# Altium schematic sheet RF Frontend v1.0.0.SchDoc("RF Frontend v1.0.0")
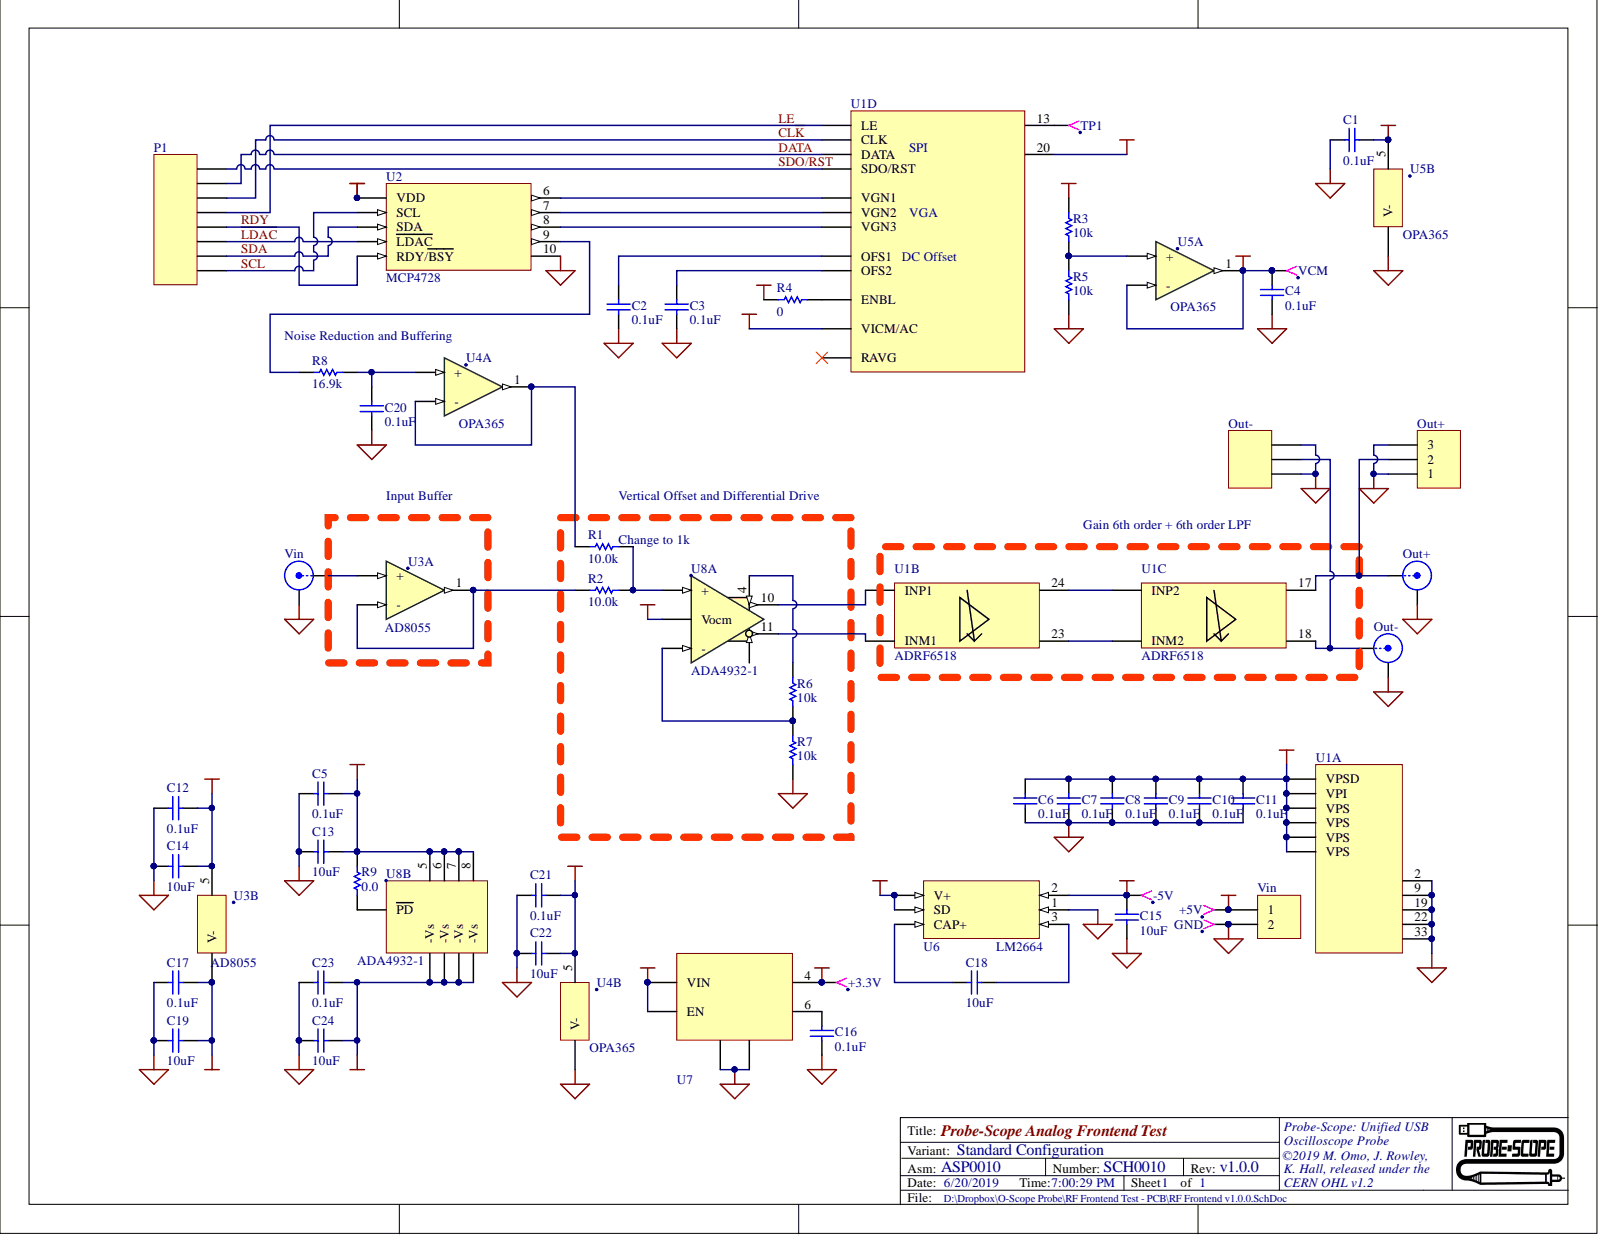
[diagram p0: RF Frontend v1.0.0.SchDoc]

## Parts
+3.3V  TP  [TESTPOINT062]  (value not drawn)
+5V  TP  [TESTPOINT062]  (value not drawn)
-5V  TP  [TESTPOINT062]  (value not drawn)
C1  value 0.1uF  [0402(1005)]  (hidden comment: Cap)
C2  value 0.1uF  [0402(1005)]  (hidden comment: Cap)
C3  value 0.1uF  [0402(1005)]  (hidden comment: Cap)
C4  value 0.1uF  [0402(1005)]  (hidden comment: Cap)
C5  value 0.1uF  [0402(1005)]  (hidden comment: Cap)
C6  value 0.1uF  [0402(1005)]  (hidden comment: Cap)
C7  value 0.1uF  [0402(1005)]  (hidden comment: Cap)
C8  value 0.1uF  [0402(1005)]  (hidden comment: Cap)
C9  value 0.1uF  [0402(1005)]  (hidden comment: Cap)
C10  value 0.1uF  [0402(1005)]  (hidden comment: Cap)
C11  value 0.1uF  [0402(1005)]  (hidden comment: Cap)
C12  value 0.1uF  [0402(1005)]  (hidden comment: Cap)
C13  value 10uF  [0603(1608)]  (hidden comment: 25V)
C14  value 10uF  [0603(1608)]  (hidden comment: 25V)
C15  value 10uF  [0603(1608)]  (hidden comment: 25V)
C16  value 0.1uF  [0402(1005)]  (hidden comment: Cap)
C17  value 0.1uF  [0402(1005)]  (hidden comment: Cap)
C18  value 10uF  [0603(1608)]  (hidden comment: 25V)
C19  value 10uF  [0603(1608)]  (hidden comment: 25V)
C20  value 0.1uF  [0402(1005)]  (hidden comment: Cap)
C21  value 0.1uF  [0402(1005)]  (hidden comment: Cap)
C22  value 10uF  [0603(1608)]  (hidden comment: 25V)
C23  value 0.1uF  [0402(1005)]  (hidden comment: Cap)
C24  value 10uF  [0603(1608)]  (hidden comment: 25V)
GND  TP  [TESTPOINT062]  (value not drawn)
Out+  SMA  [TE SMA Stright]  (value not drawn)
Out+  0.1"  [0.1HDR1X3]  (value not drawn)
Out-  SMA  [TE SMA Stright]  (value not drawn)
Out-  0.1"  [0.1HDR1X3]  (value not drawn)
P1  0.1" Vert  [0.1HDR1X8]  (value not drawn)
R1  value 10.0k  [0603(1608)]  (hidden comment: Res1)
R2  value 10.0k  [0603(1608)]  (hidden comment: Res1)
R3  value 10k  [0402(1005)]  (hidden comment: Res1)
R4  value 0  [0402(1005)]  (hidden comment: Res1)
R5  value 10k  [0402(1005)]  (hidden comment: Res1)
R6  value 10k  [0402(1005)]  (hidden comment: Res1)
R7  value 10k  [0402(1005)]  (hidden comment: Res1)
R8  value 16.9k  [0603(1608)]  (hidden comment: Res1)
R9  value 0.0  [0603(1608)]  (hidden comment: Res1)
TP1  TP  [TESTPOINT062]
U1  ADRF6518  Type: VGA  [QFN50P500X500X80_HS-33L]  (parts A,B,C,D)
U2  MCP4728  [MSOP-10]
U3  AD8055  [SOT95P280X145-5L]  (parts A,B)
U4  OPA365  [SOT23-5]  (parts A,B)
U5  OPA365  [SOT23-5]  (parts A,B)
U6  LM2664  [SOT95P280X145-6N]
U7  MIC5353  [MLF22D-06]  (value not drawn)
U8  ADA4932-1  [QFN50P300X300X80_HS-17L]  (parts A,B)
VCM  TP  [TESTPOINT062]  (value not drawn)
Vin  SMA  [TE SMA Stright]  (value not drawn)
Vin  0.1"  [0.1HDR1X2P]  (value not drawn)

## Nets
3V3: +3.3V.1 C1.2 C6.2 C7.2 C8.2 C9.2 C10.2 C11.2 C21.2 C22.2 R3.2 R4.1 U1.1(VPSD) U1.8(VPI) U1.12(VPS) U1.16(VPS) U1.25(VPS) U1.29(VPS) U2.1(VDD) U4.5 U5.5 U7.4
5V: +5V.1 C5.2 C12.2 C13.2 C14.2 R9.1 U3.5 U6.4(SD) U6.5(V+) U7.1(EN) U7.3(VIN) U8.5 U8.6 U8.7 U8.8 Vin.1
-5V: -5V.1 C15.2 C17.2 C19.2 C23.2 C24.2 U3.2(V-) U6.2 U8.13(-Vs) U8.14(-Vs) U8.15(-Vs) U8.16(-Vs)
GND: C1.1 C2.1 C3.1 C4.1 C5.1 C6.1 C7.1 C8.1 C9.1 C10.1 C11.1 C12.1 C13.1 C14.1 C15.1 C16.1 C17.1 C19.1 C20.1 C21.1 C22.1 C23.1 C24.1 GND.1 Out+.1 Out+.2 Out+.3 Out-.1 Out-.2 Out-.3 R5.1 R7.1 U1.2 U1.9 U1.19 U1.22 U1.33 U2.10 U4.2(V-) U5.2(V-) U6.1 U7.2 U7.7 Vin.2
VCM: C4.2 U1.7(VICM/AC) U1.20 U5.1 U5.4(-) U8.9(Vocm) VCM.1
CL~{K}: P1.3 U1.4(CLK)
DATA: P1.2 U1.5(DATA)
~{LDAC}: P1.6 U2.4(LDAC)
LE: P1.4 U1.3(LE)
RDY: P1.5 U2.5(RDY/BSY)
SCL: P1.8 U2.2(SCL)
SDA: P1.7 U2.3(SDA)
SDO/RST: P1.1 U1.6(SDO/RST)
NetC2_2: C2.2 U1.26(OFS1)
NetC3_2: C3.2 U1.15(OFS2)
NetC16_2: C16.2 U7.6
NetC18_1: C18.1 U6.6(CAP+)
NetC18_2: C18.2 U6.3
NetC20_2: C20.2 R8.2 U4.3(+)
NetOut+_1: Out+.1 Out+.2 U1.17
NetOut-_1: Out-.1 Out-.2 U1.18
NetR1_1: R1.1 U4.1 U4.4(-)
NetR1_2: R1.2 R2.2 U8.2(+)
NetR2_1: R2.1 U3.1 U3.4(-)
NetR3_1: R3.1 R5.2 U5.3(+)
NetR4_2: R4.2 U1.32(ENBL)
NetR6_1: R6.1 R7.2 U8.3(-)
NetR6_2: R6.2 U8.4
NetR8_1: R8.1 U2.9
NetR9_2: R9.2 U8.12(PD)
NetTP1_1: TP1.1 U1.13
NetU1_10: U1.10(INP2) U1.24
NetU1_11: U1.11(INM2) U1.23
NetU1_14: U1.14(VGN2) U2.7
NetU1_21: U1.21(VGN3) U2.8
NetU1_27: U1.27(VGN1) U2.6
NetU1_30: U1.30(INM1) U8.11
NetU1_31: U1.31(INP1) U8.10
NetU3_3: U3.3(+) Vin.1

Not connected: U1 28(RAVG); U8 1

## Library data of the parts
+3.3V +5V -5V GND TP1 VCM = 57: VENDOR_PN: 5005K-ND; VENDOR: Digikey; P&V Description: Connector, Test Point, Red, Loop, Thru Hole, 0.22", 0.063" Dia; Other: Red, Loop, Thru Hole, 0.22", 0.063" Dia; MENG_PN: CON00004; MANF_PN: 5005; MANF: Keystone Electronics; Database Table Name: Connectors; Class: Connector; Approved: True; Verified: True; Type: Test Point; SMD: False; Signal Integrity: Connector; Parent Key: 57; Library Name: MENG Database Library.DbLib; Key: 57; Date Modified: 6/23/2009 1:59:56 PM; Component Kind: Standard
C1-C12 C16 C17 C20 C21 C23 = 1603: Voltage: 16; VENDOR_PN: 1276-1004-1-ND; VENDOR: Digikey; Type: Ceramic; Tolerance: 20; P&V Description: Capacitor, Ceramic, 0.1uF, 16V, 20% Tol, 85C, 0402, SMD; MENG_PN: ???1603; Max Temperature: 85; MANF_PN: CL05F104ZO5NNNC; MANF: Samsung Electro-Mechanics; Database Table Name: Capacitors; Class: Capacitor; Approved: False; Verified: False; SMD: True; Simulation: CAP; Signal Integrity: Cap; Parent Key: 1603; Package: 0402; Library Name: MENG Database Library.DbLib; Key: 1603; Date Modified: 5/16/2019 9:44:17 PM; Component Kind: Standard; Modified By: momo
C13-C15 C18 C19 C22 C24 = 1306: Voltage: 25; VENDOR_PN: 1276-1869-1-ND; VENDOR: Digikey; Type: Ceramic; Tolerance: 20; P&V Description: Capacitor, Ceramic, 10uF, 25V, 20% Tol, 85C, X5R, 0603, SMD; Other: X5R; MENG_PN: ???1306; Max Temperature: 85; MANF_PN: CL10A106MA8NRNC; MANF: Samsung Electro-Mechanics; Database Table Name: Capacitors; Class: Capacitor; Approved: True; Verified: True; SMD: True; Simulation: CAP; Signal Integrity: Cap; Parent Key: 1306; Package: 0603; Library Name: MENG Database Library.DbLib; Key: 1306; Date Modified: 3/3/2015 11:08:29 AM; Component Kind: Standard; Modified By: sotterbacher
Out+ Out- Vin = 968: VENDOR_PN: A97594-ND; VENDOR: Digikey; Sex: Female; Positions: 1; P&V Description: Connector, SMA, 1 Positions, Female, Vertical.  50 Ohm.  3 GHz.; Other: Vertical.  50 Ohm.  3 GHz.; MENG_PN: ???968; MANF_PN: 5-1814832-1; MANF: TE Connectivity; Database Table Name: Connectors; Class: Connector; Approved: True; Verified: True; Type: SMA; SMD: False; Signal Integrity: Connector; Parent Key: 968; Library Name: MENG Database Library.DbLib; Key: 968; Date Modified: 5/28/2013 3:41:34 PM; Component Kind: Standard; Modified By: rlaity
Out+ Out- = 1134: VENDOR_PN: 952-2264-ND; VENDOR: Digikey; Spacing: 0.1; Sex: Male; Positions: 3; P&V Description: Connector, Header, 0.1", 3 Positions, Male, 0.1" Spacing, Vertical, Tin Plated; Other: Vertical, Tin Plated; MENG_PN: ???1134; MANF_PN: M20-9990346; MANF: Harwin; Database Table Name: Connectors; Class: Connector; Approved: False; Verified: False; Type: Header, 0.1"; SMD: False; Signal Integrity: Connector; Parent Key: 1134; Library Name: MENG Database Library.DbLib; Key: 1134; Date Modified: 7/21/2017 2:32:53 PM; Component Kind: Standard; Modified By: jrowly
P1 = 53: Voltage: 250; VENDOR_PN: WM6508-ND; VENDOR: Digikey; Spacing: 0.1; Positions: 8; P&V Description: Connector, Header, 0.1", 8 Positions, 0.1" Spacing, 4A, 250V, 0.24" Long, 0.125" Tail, 15u" Select Gold; Other: 0.24" Long, 0.125" Tail, 15u" Select Gold; MENG_PN: CON00006; MANF: Molex; Database Table Name: Connectors; Current: 4; Class: Connector; Approved: False; Verified: False; Type: Header, 0.1"; SMD: False; Signal Integrity: Connector; Parent Key: 53; MANF_PN: 22-28-4083; Library Name: MENG Database Library.DbLib; Key: 53; Date Modified: 7/21/2017 2:26:43 PM; Component Kind: Standard; Modified By: jrowly
R1 R2 = 739: Voltage: 50; VENDOR_PN: 311-10.0KHRCT-ND; VENDOR: Digikey; Tolerance: 1; Power: 0.1; P&V Description: Resistor, Thick Film, 10.0k Ohms, 0.1W, 1% Tol, 50V, 0603, SMD; MENG_PN: ???739; MANF_PN: RC0603FR-0710KL; MANF: Yageo; Database Table Name: Resistive Elements; Class: Resistor; Approved: True; Verified: True; Type: Thick Film; SMD: True; Simulation: RESISTOR; Signal Integrity: Res; Parent Key: 739; Package: 0603; Library Name: MENG Database Library.DbLib; Key: 739; Expr1026: 739; Date Modified: 7/7/2012 11:51:31 AM; Component Kind: Standard; Modified By: pmarcus
R3 R5-R7 = 1605: VENDOR_PN: RMCF0402FT10K0CT-ND; VENDOR: Digikey; Tolerance: 1; Power: 0.063; P&V Description: Resistor, Thick Film, 10k Ohms, 0.063W, 1% Tol, 0402, SMD; MENG_PN: ???1605; MANF_PN: RMCF0402FT10K0; MANF: Stackpole Electronics; Database Table Name: Resistive Elements; Class: Resistor; Approved: False; Verified: False; Type: Thick Film; SMD: True; Simulation: RESISTOR; Signal Integrity: Res; Parent Key: 1605; Package: 0402; Library Name: MENG Database Library.DbLib; Key: 1605; Expr1026: 1605; Date Modified: 5/16/2019 9:57:19 PM; Component Kind: Standard; Modified By: momo
R4 = 1606: VENDOR_PN: RMCF0402ZT0R00CT-ND; VENDOR: Digikey; P&V Description: Resistor, Thick Film, 0 Ohms, 0402, SMD; MENG_PN: ???1606; MANF_PN: RMCF0402ZT0R00; MANF: Stackpole Electronics; Database Table Name: Resistive Elements; Class: Resistor; Approved: False; Verified: False; Type: Thick Film; SMD: True; Simulation: RESISTOR; Signal Integrity: Res; Parent Key: 1606; Package: 0402; Library Name: MENG Database Library.DbLib; Key: 1606; Expr1026: 1606; Date Modified: 5/16/2019 9:59:31 PM; Component Kind: Standard; Modified By: momo
R8 = 1011: VENDOR_PN: P16.9KHCT-ND; VENDOR: Digikey; Tolerance: 1; Power: 0.1; P&V Description: Resistor, Thick Film, 16.9k Ohms, 0.1W, 1% Tol, 0603, SMD; MENG_PN: ???1011; MANF_PN: ERJ-3EKF1692V; MANF: Panasonic; Database Table Name: Resistive Elements; Class: Resistor; Approved: True; Verified: True; Type: Thick Film; SMD: True; Simulation: RESISTOR; Signal Integrity: Res; Parent Key: 1011; Package: 0603; Library Name: MENG Database Library.DbLib; Key: 1011; Expr1026: 1011; Date Modified: 8/12/2013 4:27:29 PM; Component Kind: Standard; Modified By: sotterbacher
R9 = 742: Voltage: 75; VENDOR_PN: RHM0.0CGCT-ND; VENDOR: Digikey; Power: 0.1; P&V Description: Resistor, Thick Film, 0.0 Ohms, 0.1W, 75V, 20m Ohm Max, 0603, SMD; Other: 20m Ohm Max; MENG_PN: ???742; MANF_PN: MCR03ERTJ000; MANF: Rohm; Database Table Name: Resistive Elements; Class: Resistor; Approved: True; Verified: True; Type: Thick Film; SMD: True; Simulation: RESISTOR; Signal Integrity: Res; Parent Key: 742; Package: 0603; Library Name: MENG Database Library.DbLib; Key: 742; Expr1026: 742; Date Modified: 3/18/2015 2:54:24 PM; Component Kind: Standard; Modified By: pmarcus
U1 = 1600: VENDOR_PN: ADRF6518ACPZ-R7CT-ND; VENDOR: Digikey; P&V Description: IC, VGA, VGA , 0Hz ~ 1.1GHz, QFN-32, SMD; Other: VGA , 0Hz ~ 1.1GHz; MENG_PN: ???1600; MANF_PN: ADRF6518ACPZ-R7; MANF: Analog Devices; Database Table Name: Analog and Mixed ICs; Class: IC; Approved: False; Verified: False; SMD: True; Parent Key: 1600; Package: QFN-32; Library Name: MENG Database Library.DbLib; Key: 1600; Date Modified: 5/16/2019 5:57:00 PM; Component Kind: Standard; Modified By: momo
U2 = 1601: VENDOR_PN: MCP4728T-E/UNCT-ND; VENDOR: Digikey; P&V Description: IC, DAC, 12 Bit, 4 Channel, MSOP-10, SMD; Other: 12 Bit, 4 Channel; MENG_PN: ???1601; MANF_PN: MCP4728T-E/UN; MANF: Microchip; Database Table Name: Analog and Mixed ICs; Class: IC; Approved: False; Verified: False; Type: DAC; SMD: True; Parent Key: 1601; Package: MSOP-10; Library Name: MENG Database Library.DbLib; Key: 1601; Date Modified: 5/16/2019 7:43:15 PM; Component Kind: Standard; Modified By: momo
U3 = 1599: VENDOR_PN: AD8055ARTZ-REEL7CT-ND; VENDOR: Digikey; P&V Description: IC, Op Amp, 300MHz, 1400V/µs, SOT-23-5, SMD; Other: 300MHz, 1400V/µs; MENG_PN: ???1599; MANF_PN: AD8055ARTZ-REEL7; MANF: Analog Devices; Database Table Name: Analog and Mixed ICs; Class: IC; Approved: False; Verified: False; Type: Op Amp; SMD: True; Parent Key: 1599; Package: SOT-23-5; Library Name: MENG Database Library.DbLib; Key: 1599; Date Modified: 5/15/2019 9:24:30 PM; Component Kind: Standard; Modified By: momo
U4 U5 = 994: VENDOR_PN: 296-20645-1-ND; VENDOR: Digikey; P&V Description: IC, Op Amp, IC OPAMP GP R-R 50MHZ SOT23-5, SOT-23-5, SMD; Other: IC OPAMP GP R-R 50MHZ SOT23-5; MENG_PN: ???994; MANF_PN: OPA365AIDBVR; MANF: Texas Instruments; Database Table Name: Analog and Mixed ICs; Class: IC; Approved: True; Verified: True; Type: Op Amp; SMD: True; Parent Key: 994; Package: SOT-23-5; Library Name: MENG Database Library.DbLib; Key: 994; Date Modified: 7/11/2013 12:18:41 PM; Component Kind: Standard; Modified By: pmarcus
U6 = 1602: VENDOR_PN: LM2664M6X/NOPBCT-ND; VENDOR: Digikey; P&V Description: IC, Voltage Regulator, Charge Pump, Inverting, SOT-23-5, SMD; Other: Charge Pump, Inverting; MENG_PN: ???1602; MANF_PN: LM2664M6X/NOPB; MANF: Texas Instruments; Database Table Name: Analog and Mixed ICs; Class: IC; Approved: False; Verified: False; Type: Voltage Regulator; SMD: True; Parent Key: 1602; Package: SOT-23-5; Library Name: MENG Database Library.DbLib; Key: 1602; Date Modified: 5/16/2019 9:05:02 PM; Component Kind: Standard; Modified By: momo
U7 = 1419: VENDOR_PN: 576-3680-1-ND; VENDOR: Digikey; P&V Description: IC, Voltage Regulator, ULDO, Low Noise, 500mA, 2.6-6Vin,, DFN-6, SMD; Other: ULDO, Low Noise, 500mA, 2.6-6Vin,; MENG_PN: ???1419; MANF_PN: MIC5353-3.3YMT-TR; MANF: Microchip; Database Table Name: Analog and Mixed ICs; Class: IC; Approved: True; Verified: True; Type: Voltage Regulator; Supplier Part Number 1: 576-3680-1-ND; Supplier 1: Digi-Key; SMD: True; Parent Key: 1419; Package: DFN-6; Library Name: MENG Database Library.DbLib; Key: 1419; Date Modified: 3/7/2016 8:56:01 AM; Component Kind: Standard; Modified By: rlaity
U8 = 1597: VENDOR_PN: ADA4932-1YCPZ-R7CT-ND; VENDOR: Digikey; P&V Description: IC, Op Amp, Differential Amplifier, 3-11V, 2800V/µs, 560MHz, QFN-16, SMD; Other: Differential Amplifier, 3-11V, 2800V/µs, 560MHz; MENG_PN: ???1597; MANF_PN: ADA4932-1YCPZ-R7; MANF: Analog Devices; Database Table Name: Analog and Mixed ICs; Class: IC; Approved: False; Verified: False; Type: Op Amp; SMD: True; Parent Key: 1597; Package: QFN-16; Library Name: MENG Database Library.DbLib; Key: 1597; Date Modified: 5/26/2019 11:36:30 AM; Component Kind: Standard; Modified By: momo
Vin = 375: Voltage: 250; VENDOR_PN: WM2700-ND; VENDOR: Digikey; Spacing: 0.1; Sex: Male; Positions: 2; P&V Description: Connector, Header, 0.1", 2 Positions, Male, 0.1" Spacing, 4A, 250V, 0.24" Long, 0.125" Tail, 15u Select Gold, Polarized, Locking Ramp; Other: 0.24" Long, 0.125" Tail, 15u Select Gold, Polarized, Locking Ramp; MENG_PN: CON00068; MANF: Molex; Database Table Name: Connectors; Current: 4; Class: Connector; Approved: False; Verified: False; Type: Header, 0.1"; SMD: False; Signal Integrity: Connector; Parent Key: 375; MANF_PN: 22-11-2022; Library Name: MENG Database Library.DbLib; Key: 375; Date Modified: 7/21/2017 2:28:28 PM; Component Kind: Standard; Modified By: jrowly
